# Altium schematic sheet 正弦波发生.SchDoc
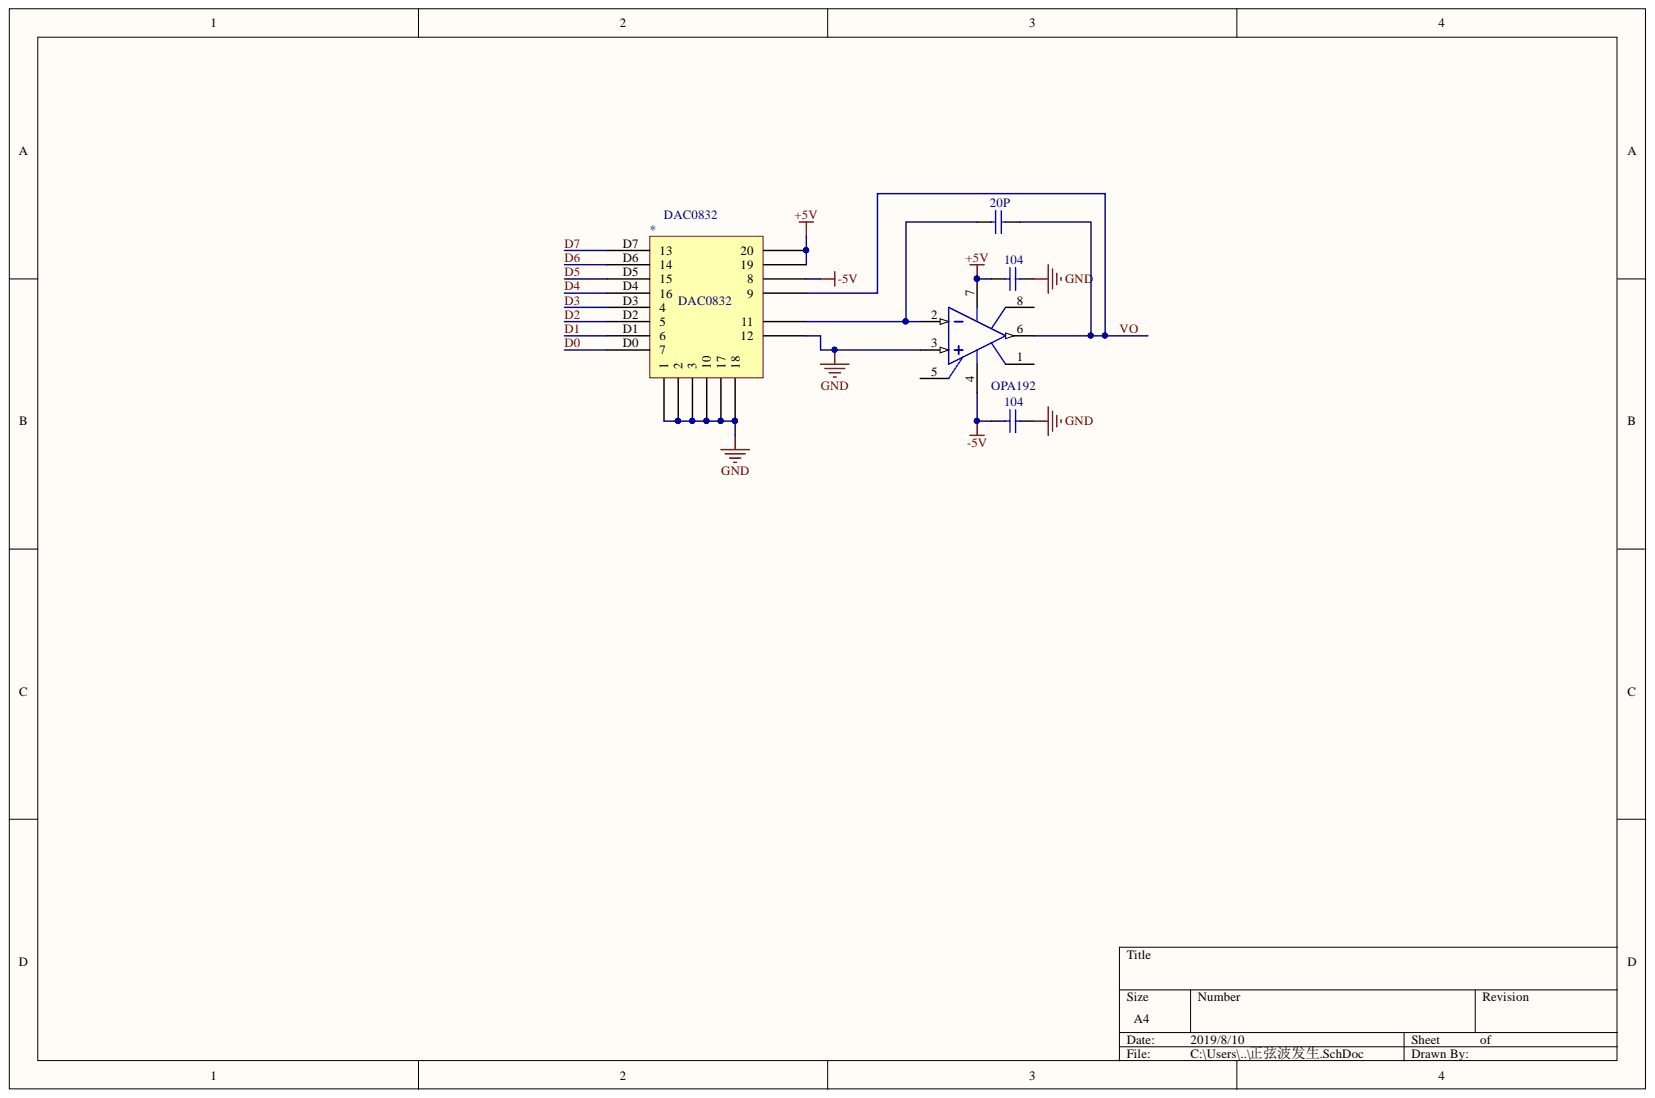
[diagram p0: 正弦波发生.SchDoc]

## Parts
20P  Cap  [RAD-0.3]  (value not drawn)
104  Cap  [RAD-0.3]  (value not drawn)
104  Cap  [RAD-0.3]  (value not drawn)
*  DAC0832
OPA192  Op Amp  [H-08A]  (value not drawn)

## Nets
+5V: 104.1 *.D14 *.D15 OPA192.7
-5V: 104.1 *.D16 OPA192.4
GND: 104.2 *.D8 *.D9 *.D10 *.D11 *.D12 *.D13 *.D19 OPA192.3
D0: *.D0
D1: *.D1
D2: *.D2
D3: *.D3
D4: *.D4
D5: *.D5
D6: *.D6
D7: *.D7
VO: 20P.2 *.D17 OPA192.6
Net*_D18: 20P.1 *.D18 OPA192.2
NetOPA192_1: OPA192.1
NetOPA192_5: OPA192.5
NetOPA192_8: OPA192.8

## Library data of the parts
20P 104 104 = Cap: Capacitor; Value: 100pF; Component Kind: Standard; LatestRevisionDate: 17-Jul-2002; LatestRevisionNote: Re-released for DXP Platform.; Library Name: Miscellaneous Devices.IntLib; PackageDescription: Radial Cap, Thru-Hole; 2 Leads; 0.3 in Pin Spacing; PackageReference: RAD-0.3; Published: 8-Jun-2000; Signal Integrity: Cap; Simulation: CAP; Publisher: Altium Limited
* = Component_1: Component Kind: Standard; Library Name: Schlib1.SchLib
OPA192 = Op Amp: FET Operational Amplifier; Component Kind: Standard; ComponentLink1Description: Manufacturer Link; ComponentLink1URL: http://www.analog.com/; DatasheetVersion: REV. B; LatestRevisionDate: 17-Jul-2002; LatestRevisionNote: Re-released for DXP Platform.; Library Name: Miscellaneous Devices.IntLib; PackageDescription: Can; 8 Leads; Pin Circle Dia. 5.08 mm; Lead Dia. 0.53 mm (max); PackageReference: H-08A; PackageVersion: 1990; Published: 8-Jun-2000; Simulation: AD645A; Publisher: Altium Limited
